# Altium schematic sheet fotopolim_v0.SchDoc(fotopolim_v0)
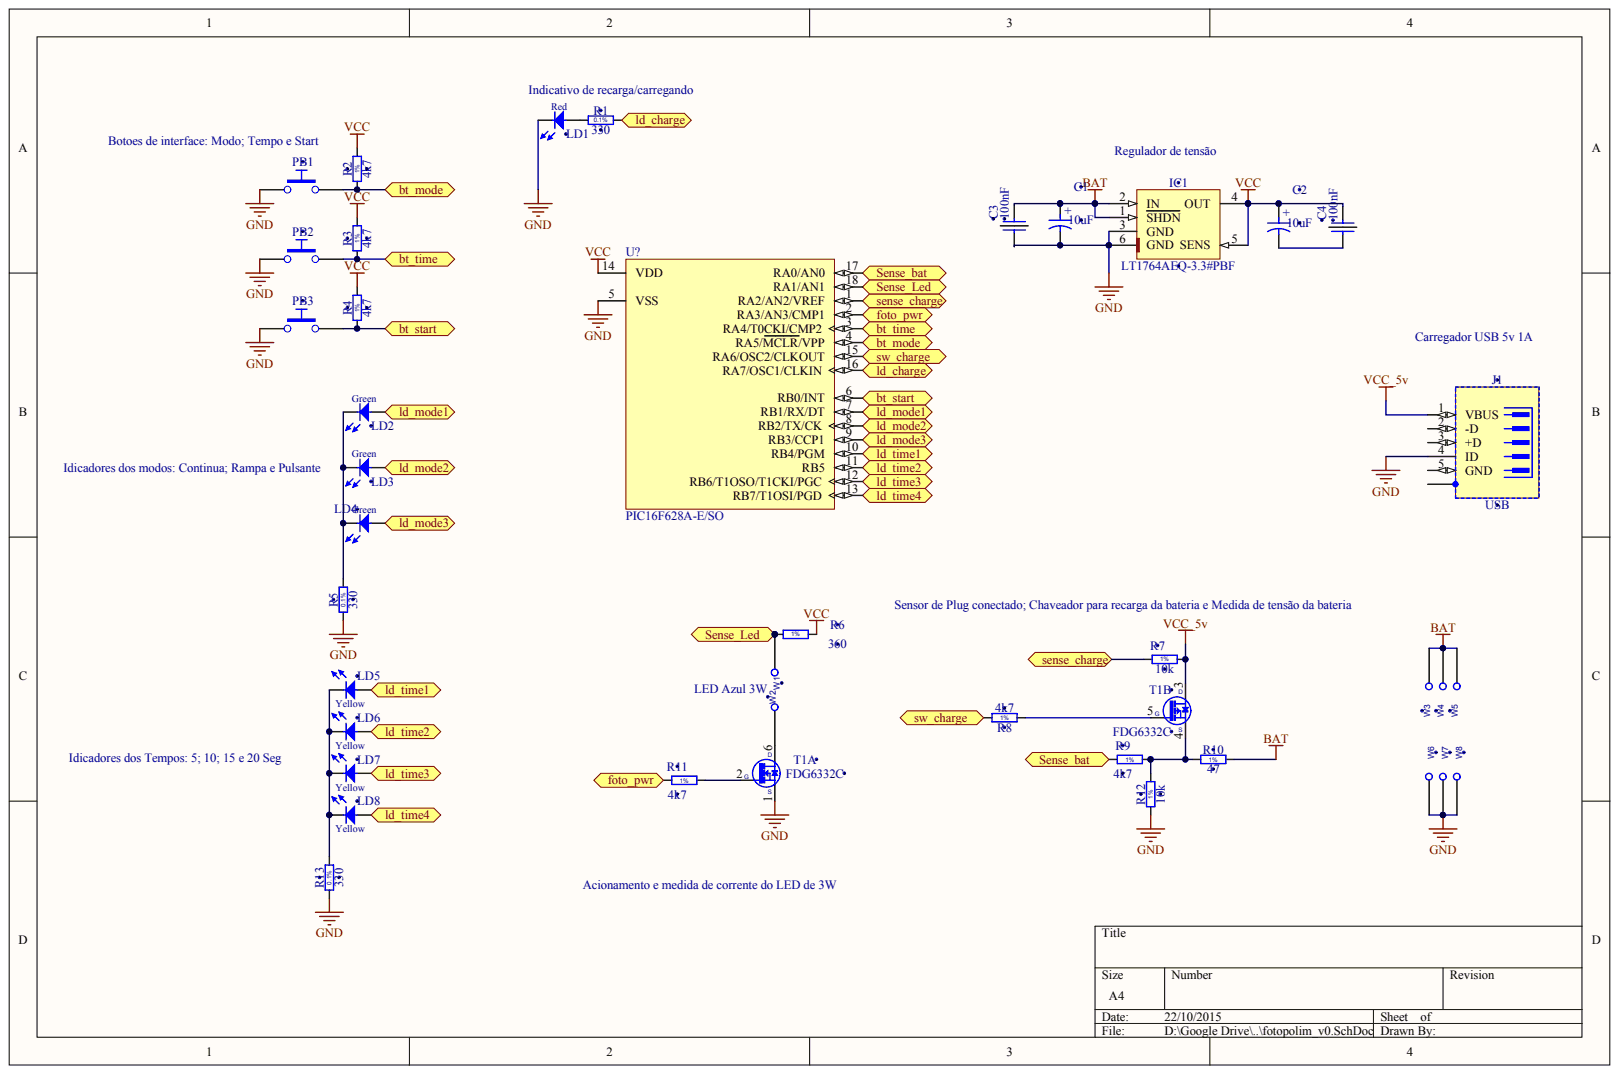
[diagram p0: fotopolim_v0.SchDoc]

## Parts
C1  10uF  [CAPMP3528X210N]
C2  10uF  [CAPMP3528X210N]
C3  100nF  [CAPC_JOHANSON_X2Y_0603]
C4  100nF  [CAPC_JOHANSON_X2Y_0603]
IC1  LT1764AEQ-3.3#PBF  [TO170P1394X457-6N-R900X1060]
J1  USB  [WURTH_651005136521]
LD1  Red  [LEDM3528X210N_RED]
LD2  Green  [LED_OSRAM_LGR971]
LD3  Green  [LED_OSRAM_LGR971]
LD4  Green  [LED_OSRAM_LGR971]
LD5  Yellow  [LEDM3528X210N_YELLOW]
LD6  Yellow  [LEDM3528X210N_YELLOW]
LD7  Yellow  [LEDM3528X210N_YELLOW]
LD8  Yellow  [LEDM3528X210N_YELLOW]
PB1  Push Button  [PB_TYCO_FSMSM]  (value not drawn)
PB2  Push Button  [PB_TYCO_FSMSM]  (value not drawn)
PB3  Push Button  [PB_TYCO_FSMSM]  (value not drawn)
R1  330  [RESC2012X60N]
R2  4k7  [RESC2012X60N]
R3  4k7  [RESC2012X60N]
R4  4k7  [RESC2012X60N]
R5  330  [RESC2012X60N]
R6  360  [RESC6331X70N]
R7  10k  [RESC2012X60N]
R8  4k7  [RESC2012X60N]
R9  4k7  [RESC2012X60N]
R10  47  [RESC6331X70N]
R11  4k7  [RESC2012X60N]
R12  10k  [RESC2012X60N]
R13  330  [RESC2012X60N]
T1  FDG6332C  [SOT65P210X110-6N]  (parts A,B)
U?  PIC16F628A-E/SO
W1  CABO COM CLIP  (value not drawn)
W2  CABO COM CLIP  (value not drawn)
W3  CABO COM CLIP  (value not drawn)
W4  CABO COM CLIP  (value not drawn)
W5  CABO COM CLIP  (value not drawn)
W6  CABO COM CLIP  (value not drawn)
W7  CABO COM CLIP  (value not drawn)
W8  CABO COM CLIP  (value not drawn)

## Ports
BT_MODE, BT_START, BT_TIME, FOTO_PWR, LD_CHARGE, LD_MODE1, LD_MODE2, LD_MODE3, LD_TIME1, LD_TIME2, LD_TIME3, LD_TIME4, SENSE_BAT, SENSE_CHARGE, SENSE_LED, SW_CHARGE

## Nets
BAT: C1.1 C3.2 IC1.1(SHDN) IC1.2(IN) R10.2 W3.1 W4.1 W5.1
GND: C1.2 C3.1 IC1.3(GND) IC1.6(GND) J1.4(ID) LD1.1 PB1.1 PB2.1 PB3.1 R5.1 R12.1 R13.1 T1.1 U?.5(VSS) W6.1 W7.1 W8.1
VCC: C2.1 C4.2 IC1.4(OUT) IC1.5(SENS) R2.2 R3.2 R4.2 R6.1 U?.14(VDD)
VCC_5V: J1.1(VBUS) R7.2 T1.3
NetC2_2: C2.2 C4.1
NetLD1_2: LD1.2 R1.1
NetLD2_1: LD2.1 LD3.1 LD4.1 R5.2
NetLD2_2: LD2.2 U?.7(RB1/RX/DT) ; port LD_MODE1
NetLD3_2: LD3.2 U?.8(RB2/TX/CK) ; port LD_MODE2
NetLD4_2: LD4.2 U?.9(RB3/CCP1) ; port LD_MODE3
NetLD5_1: LD5.1 LD6.1 LD7.1 LD8.1 R13.2
NetLD5_2: LD5.2 U?.10(RB4/PGM) ; port LD_TIME1
NetLD6_2: LD6.2 U?.11(RB5) ; port LD_TIME2
NetLD7_2: LD7.2 U?.12(RB6/T1OSO/T1CKI/PGC) ; port LD_TIME3
NetLD8_2: LD8.2 U?.13(RB7/T1OSI/PGD) ; port LD_TIME4
NetPB1_2: PB1.2 R2.1 U?.4(RA5/MCLR/VPP) ; port BT_MODE
NetPB2_2: PB2.2 R3.1 U?.3(RA4/T0CKI/CMP2) ; port BT_TIME
NetPB3_2: PB3.2 R4.1 U?.6(RB0/INT) ; port BT_START
NetR1_2: R1.2 U?.16(RA7/OSC1/CLKIN) ; port LD_CHARGE
NetR6_2: R6.2 U?.18(RA1/AN1) W2.1 ; port SENSE_LED
NetR7_1: R7.1 U?.1(RA2/AN2/VREF) ; port SENSE_CHARGE
NetR8_1: R8.1 T1.5
NetR8_2: R8.2 U?.15(RA6/OSC2/CLKOUT) ; port SW_CHARGE
NetR9_1: R9.1 U?.17(RA0/AN0) ; port SENSE_BAT
NetR9_2: R9.2 R10.1 R12.2 T1.4
NetR11_1: R11.1 U?.2(RA3/AN3/CMP1) ; port FOTO_PWR
NetR11_2: R11.2 T1.2
NetT1_6: T1.6 W1.1

Not connected: C3 G1 G2; C4 G1 G2; J1 2(-D) 3(+D) 5(GND) 6

## Library data of the parts
C1 C2 = (no symbol name): Part Number: CTE3528-21_10UF_16V_10%_LESR0800; Part Description: Low ESR 0R8 Solid Tantalum Chip Capacitor; Manufacturer: GENERIC; Manufacturer1 Part Number: TPSB106K016R0800; Voltage: 16V; Tolerance: ±10%; Table: Capacitors SMD; Component Kind: Standard; Component Type: Standard; Pin Count: 2; Value: 10uF; Status: Preferred; Manufacturer Part Number: CTE3528-21_10UF_16V_10%_LESR0800; Case: B; Mounted: Yes; Socket: No; SMD: Yes; Manufacturer1 Example: AVX; Manufacturer1 ComponentHeight: 2.1mm; HelpURL: \\cern.ch\dfs\Applications\Altium\Datasheets\CTE-LESR_AVX_TPS.pdf; CreateDate: 05/05/2008; LatestRevisionDate: 20/01/2009; Author: CERN DEM JLC
C3 C4 = (no symbol name): Part Number: CEMIF_100NF_10V_JOHANSON_100X14W104MV4T; Part Description: EMI Filter Capacitor, X2Y Series; Manufacturer: JOHANSON DIELECTRICS; Manufacturer Part Number: 100X14W104MV4T; Voltage: 10V; TC: X7R; Tolerance: ±20%; Table: Capacitors SMD; Component Kind: Standard; Component Type: Standard; Pin Count: 2; Value: 100nF; Status: None; Case: 0603; Mounted: Yes; Socket: No; SMD: Yes; ComponentHeight: 0.66mm; HelpURL: \\cern.ch\dfs\Applications\Altium\Datasheets\CEMIF_JOHANSON_X2Y.pdf; CreateDate: 08/03/2012; LatestRevisionDate: 08/03/2012; Author: CERN DEM JLC
IC1 = (no symbol name): Part Description: 3A, Fast Transient Response, Low Noise, LDO Regulators; Manufacturer: LINEAR TECHNOLOGY; Table: Regulators; Part Number: LT1764AEQ-3.3#PBF; Component Kind: Standard; Component Type: Standard; Device: LT1764AEQ-3.3#PBF; PackageDescription: TO, 1.70mm Pitch; 5 Pin, 13.945mm L X 8.89mm W X 4.572mm H body, IPC Medium Density; Manufacturer Part Number: LT1764AEQ-3.3#PBF; Pin Count: 6; Case: TO-263; Mounted: Yes; Socket: No; SMD: Yes; ComponentHeight: 4.57mm; HelpURL: \\cern.ch\dfs\Applications\Altium\Datasheets\LT1764AEQ-3.3#PBF.pdf; CreateDate: 27/03/2012; LatestRevisionDate: 27/03/2012; Author: CERN DEM JLC
J1 = (no symbol name): Part Description: Mini USB Type B  Receptacle, Horizontal, 5 Contacts; Manufacturer: WURTH ELEKTRONIK; Manufacturer Part Number: 651005136521; Table: Miscellaneous; Part Number: WURTH_651005136521; Component Kind: Standard; Component Type: Standard; Pin Count: 6; Family: USB; Mounted: Yes; Socket: No; SMD: No; Status: None; ComponentHeight: 3.9mm; HelpURL: \\cern.ch\dfs\Applications\Altium\Datasheets\WURTH_651005136521.pdf; CreateDate: 07/03/2011; LatestRevisionDate: 07/03/2011; Author: CERN DEM JLC
LD1 = (no symbol name): Part Number: LED_OSRAM_LST676-Q1R2-1; Part Description: Hyper TOPLED® SMD Super Red LED; Manufacturer: OSRAM; Manufacturer Part Number: LST676-Q1R2-1; Table: LEDs & Displays; Component Kind: Standard; Component Type: Standard; Color: Red; PackageDescription: Molded LED, 2-Leads, Body 3.5x2.8mm, IPC Medium Density PCB Edge Mounting; Pin Count: 2; Mounted: Yes; Socket: No; SMD: Yes; ComponentHeight: 1.2mm; HelpURL: \\cern.ch\dfs\Applications\Altium\Datasheets\LED_OSRAM_LST676-Q1R2-1.pdf; CreateDate: 30/06/2008; LatestRevisionDate: 17/03/2010; Author: CERN DEM JLC
LD2-LD4 = (no symbol name): Part Description: Surface Mount Green LED (0805 Case); Manufacturer: OSRAM; Manufacturer Part Number: LGR971; Table: LEDs & Displays; Part Number: LED_OSRAM_LGR971; Component Kind: Standard; Component Type: Standard; Color: Green; PackageDescription: Green Chip LED, 2-Leads, Body 2.0x1.25mm, IPC Medium Density; Pin Count: 2; Case: 0805; Mounted: Yes; Socket: No; SMD: Yes; ComponentHeight: 0.9mm; HelpURL: \\cern.ch\dfs\Applications\Altium\Datasheets\LED_OSRAM_LGR971.pdf; CreateDate: 28/01/2009; LatestRevisionDate: 17/03/2010; Author: CERN DEM JLC
LD5-LD8 = (no symbol name): Part Number: LED_OSRAM_LYT676-R1S2-26; Part Description: Hyper TOPLED® SMD Yellow LED; Manufacturer: OSRAM; Manufacturer Part Number: LY T676-R1S2-26; Table: LEDs & Displays; Component Kind: Standard; Component Type: Standard; Color: Yellow; PackageDescription: Molded LED, 2-Leads, Body 3.5x2.8mm, IPC Medium Density PCB Edge Mounting; Pin Count: 2; Mounted: Yes; Socket: No; SMD: Yes; ComponentHeight: 1.2mm; HelpURL: \\cern.ch\dfs\Applications\Altium\Datasheets\LED_OSRAM_LYT676-R1S2-26.pdf; CreateDate: 14/05/2008; LatestRevisionDate: 17/03/2010; Author: CERN DEM JLC
PB1-PB3 = (no symbol name): Part Description: 12VDC 50mA SPST Tactile  Push-Button Switch; Manufacturer: TYCO ELECTRONICS; Manufacturer Part Number: FSMSM or 1437566-3; Table: Switches; Part Number: PB_TYCO_FSMSM; Component Kind: Standard; Component Type: Standard; Pin Count: 2; PackageDescription: Body 3.5x6.0mm, Pushbutton Switch,  TYCO ALCOSWITCH FSMSM Serie; Family: Push Button; Mounted: Yes; Socket: No; SMD: Yes; Status: None; ComponentHeight: 4.3mm; HelpURL: \\cern.ch\dfs\Applications\Altium\Datasheets\PB_TYCO_FSMSM.pdf; CreateDate: 30/06/2008; LatestRevisionDate: 21/06/2012; Author: CERN DEM JLC
R1 R5 R13 = (no symbol name): Part Number: R0805_330R_0.1%_0.1W_25PPM; Part Description: Precision Surface Mount Resistor; Manufacturer: GENERIC; Manufacturer1 Part Number: PCF0805P-R-330R-BT1; Power: 0.1W; TC: ±25ppm/°C; Tolerance: ±0.1%; Table: Resistors SMD; Component Kind: Standard; Component Type: Standard; Pin Count: 2; Value: 330; Status: Not recommended; Manufacturer Part Number: R0805_330R_0.1%_0.1W_25PPM; Case: 0805; Mounted: Yes; Socket: No; SMD: Yes; Manufacturer1 Example: WELWYN COMPONENTS; Manufacturer1 ComponentHeight: 0.5mm; HelpURL: \\cern.ch\dfs\Applications\Altium\Datasheets\R0805_WELWYN_PCF0805R.pdf; CreateDate: 05/10/2010; LatestRevisionDate: 05/10/2010; Author: CERN DEM JLC
R2-R4 R8 R9 R11 = (no symbol name): Part Number: R0805_4K7_1%_0.125W_100PPM; Part Description: General Purpose Thick Film Chip Resistor; Manufacturer: GENERIC; Manufacturer1 Part Number: NRC10F4701TRF; Power: 0.125W; TC: ±100ppm/°C; Tolerance: ±1%; Table: Resistors SMD; Component Kind: Standard; Component Type: Standard; Pin Count: 2; Value: 4k7; Status: Preferred; Manufacturer Part Number: R0805_4K7_1%_0.125W_100PPM; Case: 0805; Mounted: Yes; Socket: No; SMD: Yes; Manufacturer1 Example: NIC COMPONENT; Manufacturer1 ComponentHeight: 0.6mm; HelpURL: \\cern.ch\dfs\Applications\Altium\Datasheets\R0805_NIC_NRC.pdf; CreateDate: 03/12/2007; LatestRevisionDate: 13/03/2008; Author: CERN DEM JLC
R6 = (no symbol name): Part Number: R2512_360R_1%_1W_100PPM; Part Description: Thick Film Chip Resistor; Manufacturer: GENERIC; Manufacturer1 Part Number: RC2512FK-07360RL; Power: 1W; TC: ±100ppm/°C; Tolerance: ±1%; Table: Resistors SMD; Component Kind: Standard; Component Type: Standard; Pin Count: 2; Value: 360; Status: Preferred; Manufacturer Part Number: R2512_360R_1%_1W_100PPM; Case: 2512; Mounted: Yes; Socket: No; SMD: Yes; Manufacturer1 Example: YAGEO PHYCOMP; Manufacturer1 ComponentHeight: 0.65mm; HelpURL: \\cern.ch\dfs\Applications\Altium\Datasheets\R2512_Phycomp_RC2512.pdf; CreateDate: 17/10/2012; LatestRevisionDate: 17/10/2012; Author: CERN DEM JLC
R7 R12 = (no symbol name): Part Number: R0805_10K_1%_0.125W_100PPM; Part Description: General Purpose Thick Film Chip Resistor; Manufacturer: GENERIC; Manufacturer1 Part Number: NRC10F1002TRF; Power: 0.125W; TC: ±100ppm/°C; Tolerance: ±1%; Table: Resistors SMD; Component Kind: Standard; Component Type: Standard; Pin Count: 2; Value: 10k; Status: Preferred; Manufacturer Part Number: R0805_10K_1%_0.125W_100PPM; Case: 0805; Mounted: Yes; Socket: No; SMD: Yes; Manufacturer1 Example: NIC COMPONENT; Manufacturer1 ComponentHeight: 0.6mm; HelpURL: \\cern.ch\dfs\Applications\Altium\Datasheets\R0805_NIC_NRC.pdf; CreateDate: 03/12/2007; LatestRevisionDate: 13/03/2008; Author: CERN DEM JLC
R10 = (no symbol name): Part Number: R2512_47R_1%_1W_100PPM; Part Description: Thick Film Chip Resistor; Manufacturer: GENERIC; Manufacturer1 Part Number: 232276364709L or RC2512FK-0747RL; Power: 1W; TC: ±100ppm/°C; Tolerance: ±1%; Table: Resistors SMD; Component Kind: Standard; Component Type: Standard; Pin Count: 2; Value: 47; Status: Preferred; Manufacturer Part Number: R2512_47R_1%_1W_100PPM; Case: 2512; Mounted: Yes; Socket: No; SMD: Yes; HelpURL: \\cern.ch\dfs\Applications\Altium\Datasheets\R2512_Phycomp_RC2512.pdf; CreateDate: 21/07/2008; LatestRevisionDate: 17/10/2012; Manufacturer1 Example: YAGEO PHYCOMP; Manufacturer1 ComponentHeight: 0.65mm; Author: CERN DEM JLC
T1 = (no symbol name): Part Description: 20V N & P-Channel PowerTrench® MOSFETs; Manufacturer: FAIRCHILD SEMICONDUCTOR; Table: Transistors; Part Number: FDG6332C; Component Kind: Standard; Component Type: Standard; Device: FDG6332C; PackageDescription: SOT363, 0.65mm pitch; 6 pin, 1.40mm W X 2.15mm L, IPC Medium Density; Manufacturer Part Number: FDG6332C; Pin Count: 6; Case: SOT363; Mounted: Yes; Socket: No; SMD: Yes; ComponentHeight: 1.1mm; HelpURL: \\cern.ch\dfs\Applications\Altium\Datasheets\FDG6332C.pdf; CreateDate: 25/11/2008; LatestRevisionDate: 25/11/2008; Author: CERN DEM JLC
W1-W8 = (no symbol name): cod: 300051572; 3D comp: CABO COM CLIP; Compra: 300051572; Descri: CABO COM CLIP; SCH: CABO COM CLIP
U? = (no symbol name): Published: 11-Oct-2004; LatestRevisionDate: 29-Jan-2009; LatestRevisionNote: Sch. layout revised. 3D Model Removed.; DatasheetVersion: revF, Mar-2007; PackageVersion: revBB, Aug-2009; PackageReference: SOIC-SO18; PackageDescription: 18-Lead Plastic Small Outline (SO) - Wide 7.50mm Body [SOIC]; Supplier 1: Digi-Key; Supplier Part Number 1: PIC16F628A-E/SO-ND; Supplier 2: Farnell; Supplier Part Number 2: 1815860; Code_JEDEC: MS-013; ComponentLink1Description: Datasheet; ComponentLink1URL: http://ww1.microchip.com/downloads/en/DeviceDoc/40044F.pdf; ComponentLink2Description: Packaging Specification; ComponentLink2URL: http://www.microchip.com/stellent/groups/techpub_sg/documents/packagingspec/en012702.pdf; ComponentLink3Description: Microchip.com Home; ComponentLink3URL: http://www.microchip.com/; Publisher: Altium Limited
